# Altium schematic sheet HorsPCB.SchDoc
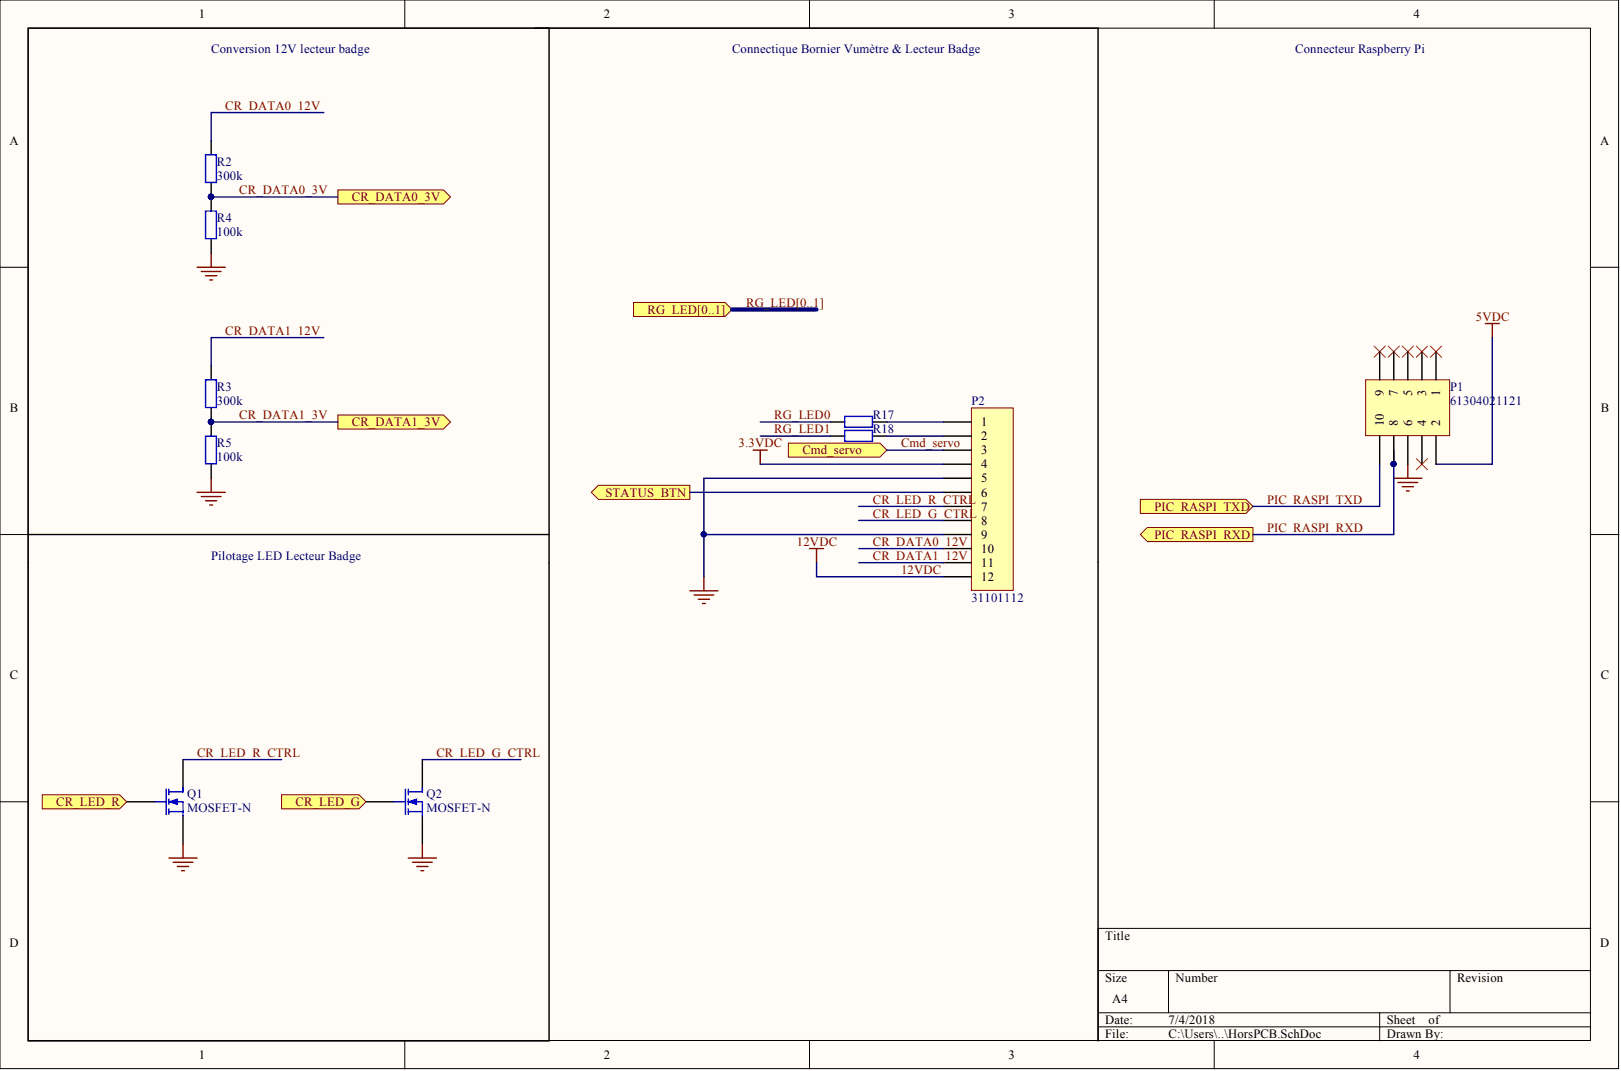
[diagram p0: HorsPCB.SchDoc]

## Parts
P1  61304021121  [HDR2X5]
P2  31101112  [Bornier12]
Q1  MOSFET-N  [nouveau_mosfetN]
Q2  MOSFET-N  [nouveau_mosfetN]
R2  300k  [0603]
R3  300k  [0603]
R4  100k  [0603]
R5  100k  [0603]
R17  60.4  [0603]  (value not drawn)
R18  75  [0603]  (value not drawn)

## Ports
CMD_SERVO, CR_DATA0_3V, CR_DATA1_3V, CR_LED_G, CR_LED_R, PIC_RASPI_RXD, PIC_RASPI_TXD, RG_LED0, RG_LED1, RG_LED[0..1], STATUS_BTN

## Nets
3.3VDC: P2.4
5VDC: P1.2
GND: P1.6 P2.5 P2.9 Q1.3 Q2.3 R4.1 R5.1
12VDC: P2.12
CMD_SERVO: P2.3 ; port CMD_SERVO
CR_DATA0_3V: R2.1 R4.2 ; port CR_DATA0_3V
CR_DATA0_12V: P2.10 R2.2
CR_DATA1_3V: R3.1 R5.2 ; port CR_DATA1_3V
CR_DATA1_12V: P2.11 R3.2
CR_LED_G: Q2.2 ; port CR_LED_G
CR_LED_G_CTRL: P2.8 Q2.1
CR_LED_R: Q1.2 ; port CR_LED_R
CR_LED_R_CTRL: P2.7 Q1.1
PIC_RASPI_RXD: P1.8 ; port PIC_RASPI_RXD
PIC_RASPI_TXD: P1.10 ; port PIC_RASPI_TXD
RG_LED0: R17.1 ; port RG_LED[0..1]  [also labelled RG_LED]
RG_LED1: R18.1 ; port RG_LED[0..1]  [also labelled RG_LED]
STATUS_BTN: P2.6 ; port STATUS_BTN
NetP2_1: P2.1 R17.2
NetP2_2: P2.2 R18.2

Not connected: P1 1 3 4 5 7 9

## Library data of the parts
P1 = Header 5X2: Header, 5-Pin, Dual row; Fabricant: WURTH ELEKTRONIK; Fournisseur: Farnell; Ref.Fournisseur: 2356148; Component Kind: Standard; Library Name: Miscellaneous Connectors.IntLib; Ref.Fabricant: 61304021121; Signal Integrity: Connector
P2 = Header 12: Header, 12-Pin; Fabricant: METZ CONNECT; Fournisseur: Farnell; Ref.Fournisseur: 1909750; Component Kind: Standard; Library Name: Miscellaneous Connectors.IntLib; Ref.Fabricant: 31101112; Signal Integrity: Connector
Q1 Q2 = MOSFET-N: N-Channel MOSFET; Fabricant: ON SEMICONDUCTOR; Fournisseur: Farnell; Ref.Fabricant: 1HN04CH-TL-W; Ref.Fournisseur: 2727912; Component Kind: Standard; Library Name: Miscellaneous Devices.IntLib; Simulation: NMOS
R2 R3 = Res2: Resistor; Fabricant: MULTICOMP; Fournisseur: Farnell; Ref.Fabricant: MCSR08X3003FTL; Ref.Fournisseur: 2074433; Component Kind: Standard; Library Name: Miscellaneous Devices.IntLib; Signal Integrity: Res; Simulation: RESISTOR; Value: 300k
R4 R5 = Res2: Resistor; Fabricant: MULTICOMP; Fournisseur: Farnell; Ref.Fabricant: MCWR06X1003FTL; Ref.Fournisseur: 2447226; Component Kind: Standard; Library Name: Miscellaneous Devices.IntLib; Signal Integrity: Res; Simulation: RESISTOR; Value: 100k
R17 = Res2: Resistor; Fabricant: TE CONNECTIVITY; Fournisseur: Farnell; Ref.Fabricant: RP73PF1E60R4BTDF; Ref.Fournisseur: 2838800; Value: 60.4o; Component Kind: Standard; Library Name: Miscellaneous Devices.IntLib; Signal Integrity: Res; Simulation: RESISTOR
R18 = Res2: Resistor; Fabricant: TE CONNECTIVITY; Fournisseur: Farnell; Ref.Fabricant: CPF0805B75RE1; Ref.Fournisseur: 1697415; Value: 75o; Component Kind: Standard; Library Name: Miscellaneous Devices.IntLib; Signal Integrity: Res; Simulation: RESISTOR
